# Altium schematic sheet lv_io_lim.SchDoc
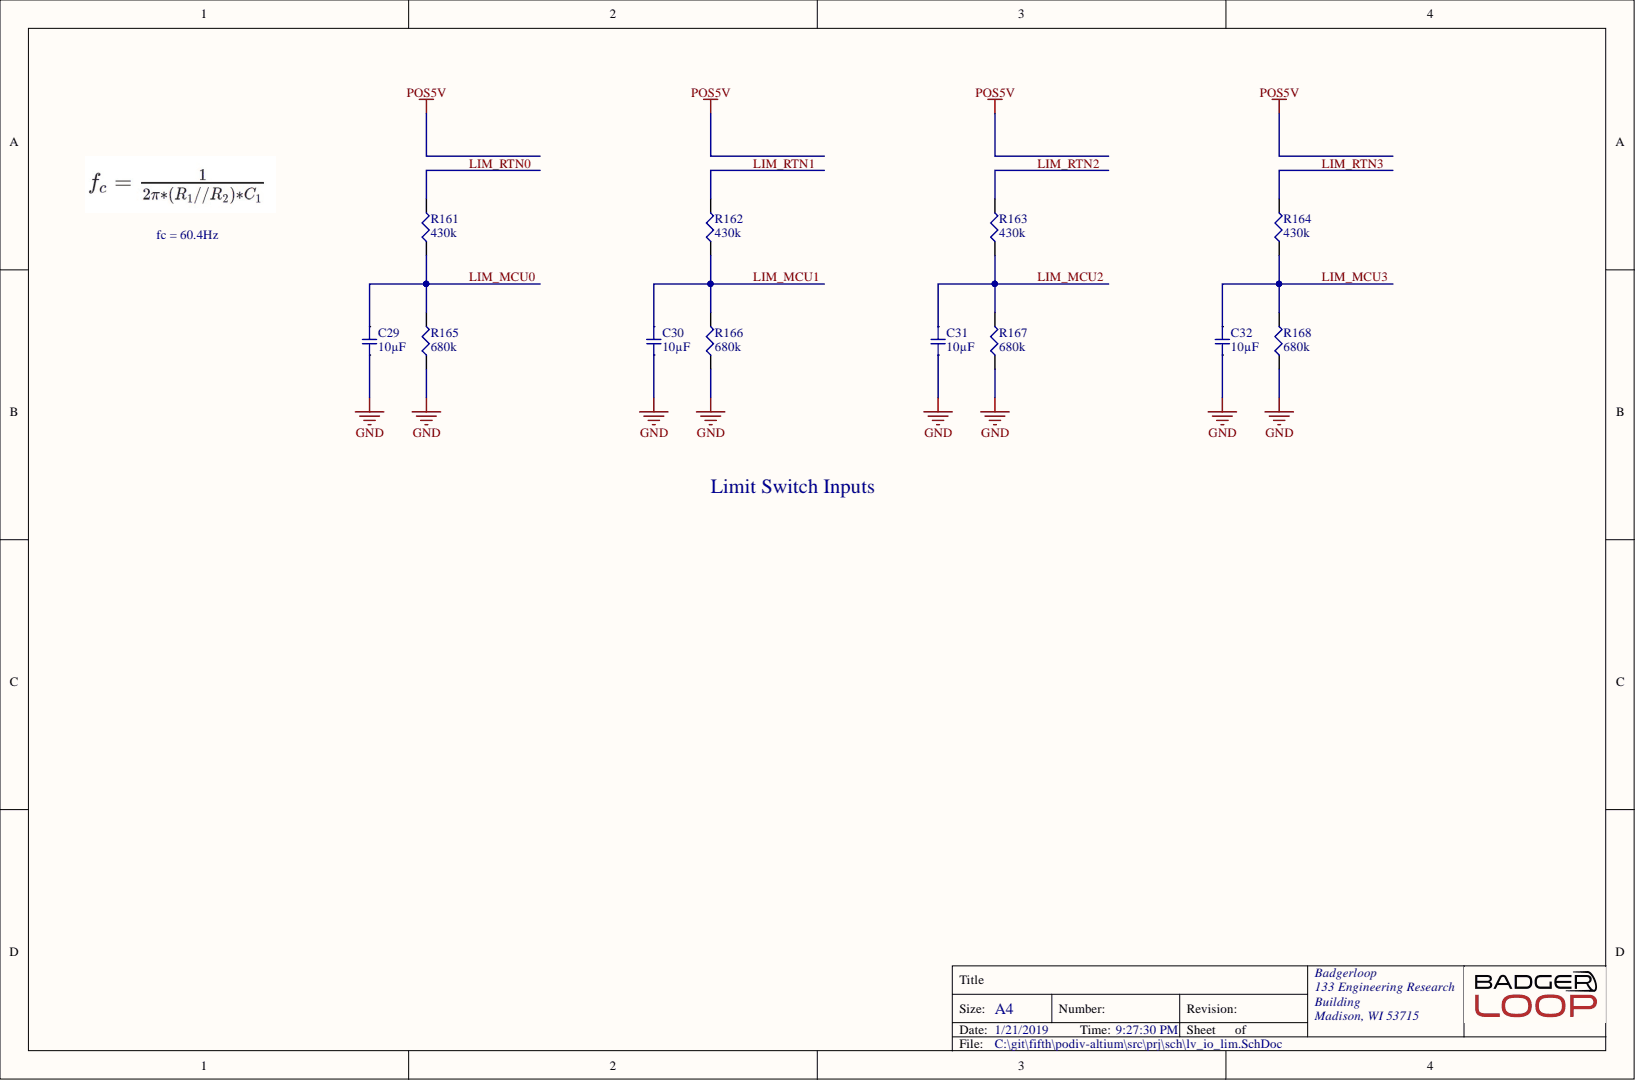
[diagram p0: lv_io_lim.SchDoc]

## Parts
C29  10µF  [SMD-0805C]
C30  10µF  [SMD-0805C]
C31  10µF  [SMD-0805C]
C32  10µF  [SMD-0805C]
R161  430k  [SMD-0402-RES]
R162  430k  [SMD-0402-RES]
R163  430k  [SMD-0402-RES]
R164  430k  [SMD-0402-RES]
R165  680k  [SMD-0402-RES]
R166  680k  [SMD-0402-RES]
R167  680k  [SMD-0402-RES]
R168  680k  [SMD-0402-RES]

## Nets
GND: C29.2 C30.2 C31.2 C32.2 R165.1 R166.1 R167.1 R168.1
LIM_MCU0: C29.1 R161.1 R165.2
LIM_MCU1: C30.1 R162.1 R166.2
LIM_MCU2: C31.1 R163.1 R167.2
LIM_MCU3: C32.1 R164.1 R168.2
LIM_RTN0: R161.2
LIM_RTN1: R162.2
LIM_RTN2: R163.2
LIM_RTN3: R164.2
POS5V:

## Library data of the parts
C29-C32 = GRM21BC81E106KE51L: CAP CER 10UF 25V X6S 0805; Manufacturer: Murata Electronics North America; @ qty: 0; Minimum Quantity: 1; Tolerance: ±10%; Unit Price (USD): 0.25; Applications: General Purpose; Component Kind: Standard; Datasheets: http://www.murata.com/~/media/webrenewal/support/library/catalog/products/capacitor/mlcc/c02e.pdf; Digi-Key Part Number: 490-10502-1-ND; Factory Stock: 0; Features: Derating Recommended; Height - Seated (Max): -; Image: //media.digikey.com/Renders/Murata%20Renders/0805%20(2012%20Metric)%20.85mm.jpg; Lead Spacing: -; Lead Style: -; Library Name: capacitor.SchLib; Mounting Type: Surface Mount, MLCC; Operating Temperature: -55°C ~ 105°C; Package / Case: 0805 (2012 Metric); Packaging: Cut Tape (CT); Part Number: GRM21BC81E106KE51L; Part Status: Active; Quantity Available: 230; Ratings: -; Series: GRM; Size / Dimension: 0.079" L x 0.049" W (2.00mm x 1.25mm); Temperature Coefficient: X6S; Thickness (Max): 0.055" (1.40mm); Value: 10µF; Voltage: 25V
R161-R164 = ERJ-2GEJ434X: RES SMD 430K OHM 5% 1/10W 0402; Manufacturer: Panasonic Electronic Components; @ qty: 1500; Factory Stock: 0; Minimum Quantity: 1; Power (Watts): 0.1W, 1/10W; Temperature Coefficient: ±200ppm/°C; Unit Price (USD): 0.00350; Component Kind: Standard; Composition: Thick Film; Datasheets: http://industrial.panasonic.com/www-cgi/jvcr13pz.cgi?E+PZ+3+AOA0001+ERJ2GEJ434X+7+WW; Digi-Key Part Number: P430KJCT-ND; Failure Rate: -; Features: Automotive AEC-Q200; Height - Seated (Max): 0.016" (0.40mm); Image: //media.digikey.com/Photos/Panasonic%20Photos/ERJ-PA2-Series.jpg; Library Name: resistor.SchLib; Number of Terminations: 2; Operating Temperature: -55°C ~ 155°C; Package / Case: 0402 (1005 Metric); Packaging: Cut Tape (CT); Part Number: ERJ-2GEJ434X; Part Status: Active; Quantity Available: 99138; Series: ERJ; Size / Dimension: 0.039" L x 0.020" W (1.00mm x 0.50mm); Supplier Device Package: 0402; Tolerance: ±5%; Value: 430k
R165-R168 = ERJ-2GEJ684X: RES SMD 680K OHM 5% 1/10W 0402; Manufacturer: Panasonic Electronic Components; @ qty: 1500; Factory Stock: 0; Minimum Quantity: 1; Power (Watts): 0.1W, 1/10W; Temperature Coefficient: ±200ppm/°C; Unit Price (USD): 0.00350; Component Kind: Standard; Composition: Thick Film; Datasheets: http://industrial.panasonic.com/www-cgi/jvcr13pz.cgi?E+PZ+3+AOA0001+ERJ2GEJ684X+7+WW; Digi-Key Part Number: P680KJCT-ND; Failure Rate: -; Features: Automotive AEC-Q200; Height - Seated (Max): 0.016" (0.40mm); Image: //media.digikey.com/Photos/Panasonic%20Photos/ERJ-PA2-Series.jpg; Library Name: resistor.SchLib; Number of Terminations: 2; Operating Temperature: -55°C ~ 155°C; Package / Case: 0402 (1005 Metric); Packaging: Cut Tape (CT); Part Number: ERJ-2GEJ684X; Part Status: Active; Quantity Available: 77606; Series: ERJ; Size / Dimension: 0.039" L x 0.020" W (1.00mm x 0.50mm); Supplier Device Package: 0402; Tolerance: ±5%; Value: 680k
